# Altium schematic sheet PAGE2 - PWR, MODULE.SchDoc (continued)

## Library data of the parts
C1 C3 C8 = GRM21BR61A476ME15L: MURATA - GRM21BR61A476ME15L - CAP, MLCC, X5R, 47UF, 10V, 0805; Capacitance: 47; Capacitance Tolerance: ± 20%; Ceramic Capacitor Case: 0805 [2012 Metric]; Dielectric Characteristic: X5; Product Range: GRM Series; SVHC: No SVHC (17-Dec-2015; Voltage Rating: 10; Automotive Qualification Standard: -; Component Kind: Standard; ComponentLink1Description: http://www.farnell.com/datasheets/2079183.pdf; ComponentLink1URL: http://www.farnell.com/datasheets/2079183.pdf; Library Name: SCHLib.SchLib; Manufacturer: MURATA; Manufacturer Part Number: GRM21BR61A476ME15L; MSL: MSL 1 - Unlimited; Operating Temperature Max: 85; Operating Temperature Min: -55; Packaging: Cut Tape; Pricing 1: 5=0.699, 50=0.295, 250=0.232, 500=0.193, 1500=0.171 (GBP); RoHS: YES; Stock 1: 27604; Supplier 1: Farnell; Supplier Part Number 1: 2611939
C2 C4-C6 C20 = CGA4J1X5R1C106K125AC: TDK - CGA4J1X5R1C106K125AC - CAP, MLCC, X5R, 10UF, 16V, 0805; Component Kind: Standard; ComponentLink1Description: http://bcove.me/e1v54mtm; ComponentLink1URL: http://bcove.me/e1v54mtm; ComponentLink2Description: http://www.farnell.com/datasheets/1936565.pdf; ComponentLink2URL: http://www.farnell.com/datasheets/1936565.pdf; ComponentLink3Description: http://www.farnell.com/datasheets/1683658.pdf; ComponentLink3URL: http://www.farnell.com/datasheets/1683658.pdf; Library Name: SCHLib.SchLib; Manufacturer: TDK; Manufacturer Part Number: CGA4J1X5R1C106K125AC; Pricing 1: 5=0.41, 50=0.146, 250=0.131, 500=0.12, 1000=0.103 (GBP); RoHS: YES; Stock 1: 8974; Supplier 1: Farnell; Supplier Part Number 1: 2210948RL
C7 C16 C21 = 100nF: MURATA - GRM188R71C104KA01J - CAP, MLCC, X7R, 0.1UF, 16V, 0603; Capacitance: 0.1; Capacitance Tolerance: ± 10%; Ceramic Capacitor Case: 0603 [1608 Metric]; Dielectric Characteristic: X7; Product Range: GRM Series; SVHC: No SVHC (20-Jun-2016; Voltage Rating: 16; Automotive Qualification Standard: -; Component Kind: Standard; ComponentLink1Description: http://www.farnell.com/datasheets/2189124.pdf; ComponentLink1URL: http://www.farnell.com/datasheets/2189124.pdf; Library Name: SCHLib.SchLib; Manufacturer: MURATA; Manufacturer Part Number: GRM188R71C104KA01J; MSL: MSL 1 - Unlimited; Operating Temperature Max: 125; Operating Temperature Min: -55; Packaging: Cut Tape; Pricing 1: 10=0.0145, 100=0.0126, 500=0.0081, 2500=0.0059, 5000=0.0052, 10000=0.0046, 50000=0.0036, 100000=0.0035 (GBP); RoHS: YES; Stock 1: 18297; Supplier 1: Farnell; Supplier Part Number 1: 2688519
C9 C10 = GRM188R61C105KA12D: MURATA - GRM188R61C105KA12D - CAP, MLCC, X5R, 1UF, 16V, 0603; Capacitance: 1; Capacitance Tolerance: ± 10%; Capacitance Tolerance ±: ± 10%<!--FANDB--><div class="features-and-benefits-container"><div class="features-and-benefits-description"><span class="features-benefits-value">The Murata GRM series capacitors are Multilayer Ceramic Chip Capacitors (MLCC) used for general purpose applications. These MLCC capacitors are classified into temperature compensating and high dielectric constant types depending on the change in electrostatic capacitance due to change in temperature. The temperature compensating capacitors feature a small rate of change in electrostatic capacitance as the temperature changes and are used for applications such as filters and high frequency circuit matching. The high dielectric constant capacitors use materials with a high dielectric constant and feature a large electrostatic capacitance. Typical applications are power supply decoupling and smoothing circuits.</span></div><div class="features-and-benefits-list"><ul class="features-and-benefits-list-list"><li class="features-and-benefits-list-item">Large capacity and small size in a multilayer structure</li><li class="features-and-benefits-list-item">Capacitance value ranging from 0.1pF to 220?F</li><li class="features-and-benefits-list-item">Tin plated external electrodes, excellent solderability</li><li class="features-and-benefits-list-item">Provides good high frequency characteristics</li><li class="features-and-benefits-list-item">Excellent pulse response</li><li class="features-and-benefits-list-item">High reliability</li><li class="features-and-benefits-list-item">Capacitor size varies from 0.4×0.2mm to 5.7×5.0mm</li><li class="features-and-benefits-list-item">Rated voltage of 2.5VDC to 3.15; Ceramic Capacitor Case: 0603 [1608 Metric]; Dielectric Characteristic: X5; Product Range: GRM Series; SVHC: No SVHC (17-Dec-2015); Voltage Rating: 16; Automotive Qualification Standard: -; Component Kind: Standard; ComponentLink1Description: http://www.farnell.com/datasheets/2047881.pdf; ComponentLink1URL: http://www.farnell.com/datasheets/2047881.pdf; ComponentLink2Description: http://www.farnell.com/datasheets/2006461.pdf; ComponentLink2URL: http://www.farnell.com/datasheets/2006461.pdf; Library Name: SCHLib.SchLib; Manufacturer: MURATA; Manufacturer Part Number: GRM188R61C105KA12D; MSL: MSL 1 - Unlimited; Operating Temperature Max: 85; Operating Temperature Min: -55; Packaging: Cut Tape; Pricing 1: 10=0.0194, 100=0.013, 500=0.0101, 1000=0.0078, 2000=0.0074 (GBP); RoHS: YES; Stock 1: 27380; Supplier 1: Farnell; Supplier Part Number 1: 2362099RL
C11 C12 = GRM188R61C106MA73D: MURATA - GRM188R61C106MA73D - CAP, MLCC, X5R, 10UF, 16V, 0603; Capacitance: 10; Capacitance Tolerance: ± 20%; Ceramic Capacitor Case: 0603 [1608 Metric]; Dielectric Characteristic: X5; Product Range: GRM Series; SVHC: No SVHC (17-Dec-2015)<!--FANDB--><div class="features-and-benefits-container"><div class="features-and-benefits-description"><span class="features-benefits-value">The Murata GRM series capacitors are Multilayer Ceramic Chip Capacitors (MLCC) used for general purpose applications. These MLCC capacitors are classified into temperature compensating and high dielectric constant types depending on the change in electrostatic capacitance due to change in temperature. The temperature compensating capacitors feature a small rate of change in electrostatic capacitance as the temperature changes and are used for applications such as filters and high frequency circuit matching. The high dielectric constant capacitors use materials with a high dielectric constant and feature a large electrostatic capacitance. Typical applications are power supply decoupling and smoothing circuits.</span></div><div class="features-and-benefits-list"><ul class="features-and-benefits-list-list"><li class="features-and-benefits-list-item">Large capacity and small size in a multilayer structure</li><li class="features-and-benefits-list-item">Capacitance value ranging from 0.1pF to 220?F</li><li class="features-and-benefits-list-item">Tin plated external electrodes, excellent solderability</li><li class="features-and-benefits-list-item">Provides good high frequency characteristics</li><li class="features-and-benefits-list-item">Excellent pulse response</li><li class="features-and-benefits-list-item">High reliability</li><li class="features-and-benefits-list-item">Capacitor size varies from 0.4×0.2mm to 5.7×5.0mm</li><li class="features-and-benefits-list-item">Rated voltage of 2.5VDC to 3.15; Voltage Rating: 16; Automotive Qualification Standard: -; Component Kind: Standard; ComponentLink1Description: http://www.farnell.com/datasheets/2048226.pdf; ComponentLink1URL: http://www.farnell.com/datasheets/2048226.pdf; Library Name: SCHLib.SchLib; Manufacturer: MURATA; Manufacturer Part Number: GRM188R61C106MA73D; MSL: -; Operating Temperature Max: 85; Operating Temperature Min: -55; Packaging: Cut Tape; Pricing 1: 10=0.181, 100=0.103, 500=0.0863, 1000=0.0778, 2000=0.0677 (GBP); RoHS: YES; Stock 1: 21735; Supplier 1: Farnell; Supplier Part Number 1: 2426958
C13 C17 = C1206C221KGRACTU: KEMET - C1206C221KGRACTU - CAP, MLCC, X7R, 220PF, 2KV, 1206; Component Kind: Standard; ComponentLink1Description: http://www.farnell.com/datasheets/2180568.pdf; ComponentLink1URL: http://www.farnell.com/datasheets/2180568.pdf; Library Name: SCHLib.SchLib; Manufacturer: KEMET; Manufacturer Part Number: C1206C221KGRACTU; Pricing 1: 5=0.153, 50=0.0991, 250=0.0793, 500=0.0671, 1000=0.0581, 2500=0.0484, 12500=0.0415, 25000=0.0363 (GBP); RoHS: YES; Stock 1: 1984; Supplier 1: Farnell; Supplier Part Number 1: 2676444RL
C14 C15 C19 = GRM31CR61H106KA12L: MURATA - GRM31CR61H106KA12L - CAP, MLCC, X5R, 10UF, 50V, 1206; Component Kind: Standard; ComponentLink1Description: http://www.farnell.com/datasheets/2048767.pdf; ComponentLink1URL: http://www.farnell.com/datasheets/2048767.pdf; Library Name: SCHLib.SchLib; Manufacturer: MURATA; Manufacturer Part Number: GRM31CR61H106KA12L; Pricing 1: 5=0.368, 50=0.214, 250=0.196, 500=0.178, 1000=0.159 (GBP); RoHS: YES; Stock 1: 51830; Supplier 1: Farnell; Supplier Part Number 1: 1845762
C18 = GRM21BR71C105KA01L: MURATA - GRM21BR71C105KA01L - CAP, MLCC, X7R, 1UF, 16V, 0805; Component Kind: Standard; ComponentLink1Description: http://www.farnell.com/datasheets/2047889.pdf; ComponentLink1URL: http://www.farnell.com/datasheets/2047889.pdf; ComponentLink2Description: http://www.farnell.com/cad/1547313.zip; ComponentLink2URL: http://www.farnell.com/cad/1547313.zip; ComponentLink3Description: http://www.farnell.com/datasheets/2006461.pdf; ComponentLink3URL: http://www.farnell.com/datasheets/2006461.pdf; Library Name: SCHLib.SchLib; Manufacturer: MURATA; Manufacturer Part Number: GRM21BR71C105KA01L; Pricing 1: 5=0.0398, 50=0.0331, 250=0.0235, 500=0.022, 1500=0.018 (GBP); RoHS: YES; Stock 1: 58053; Supplier 1: Farnell; Supplier Part Number 1: 9527710
CM1 = RPI COMPUTE MODULE: RASPBERRY-PI - RPI COMPUTE MODULE - COMPUTE MODULE, RASPBERRY PI DEV BOARD; Silicon Manufacturer: Broadcom; Core Architecture: ARM; Core Sub-Architecture: ARM1176; For Use With: Raspberry Pi Compute Development Kit; Kit Contents: Compute Module BCM2835; Kit Features: Flexible & Rapid Prototype Development, Small & Powerful, Many More GPIOs & Interfaces<!--FANDB--><div class="features-and-benefits-container"><div class="features-and-benefits-desc-container"><span class="features-and-benefits-container-desc">Raspberry Pi Compute Module</span></div><div class="features-and-benefits-description"><span class="features-benefits-value">Flexible & Rapid Prototype Development, Small & Powerful, Many More GPIOs & Interfaces</span></div><div class="features-and-benefits-list"><ul class="features-and-benefits-list-list"><li class="features-and-benefits-list-item">Flexible & Rapid Prototype Development, Small & Powerful, Many More GPIOs & Interfaces</li></ul></div></div>; Silicon Core Number: BCM2835; Silicon Family Name: BCM2; ComponentLink1Description: http://bcove.me/qikksixg; ComponentLink1URL: http://bcove.me/qikksixg; ComponentLink2Description: http://www.farnell.com/datasheets/1829397.pdf; ComponentLink2URL: http://www.farnell.com/datasheets/1829397.pdf; Features: 512MB RAM, SODIMM Sized 6.5cm by 3cm Board, 200pin Edge Connector, On-board 4; Library Name: SCHLib.SchLib; Manufacturer: RASPBERRY-PI; Manufacturer Part Number: RPI COMPUTE MODULE; Pricing 1: 1=19.5 (GBP); Product Range: -; RoHS: YES; Supplier 1: Farnell; Supplier Part Number 1: 2427122
CON1 = RPi CM CON: DDR2 SODIMM connector for Raspberry Pi compute module 1/3/3-Lite; Manufacturer: AMP - TE CONNECTIVITY; Connector Type: Memory Socket; Contact Termination: Surface Mount Right Angle; Contact Termination Type: Surface Mount; No. of Contacts: 200; Pitch Spacing: 0.6; Range: Standard; Row Pitch: 6.2mm<!--FANDB--><div class="features-and-benefits-container"><div class="features-and-benefits-description"><span class="features-benefits-value">The 1473005-4 from TE Connectivity is a standard type DDR2 SODIMM socket. It is a 0.6mm pitch, 200 positions, semi hard tray DDR2 socket.</span></div><div class="features-and-benefits-list"><ul class="features-and-benefits-list-list"><li class="features-and-benefits-list-item">Right angled orientation</li><li class="features-and-benefits-list-item">2 rows and 2 bays</li><li class="features-and-benefits-list-item">DRAM voltage and SGRAM voltage?is 1.8; SVHC: No SVHC (15-Jun-2015); Component Kind: Standard; ComponentLink1Description: http://www.farnell.com/datasheets/2258267.pdf; ComponentLink1URL: http://www.farnell.com/datasheets/2258267.pdf; ComponentLink2Description: http://www.farnell.com/cad/1649684.pdf; ComponentLink2URL: http://www.farnell.com/cad/1649684.pdf; ComponentLink3Description: http://www.farnell.com/datasheets/582309.pdf; ComponentLink3URL: http://www.farnell.com/datasheets/582309.pdf; Contact Material: Copper; Contact Plating: Gold Plated Contacts; Lead Spacing: 0.6; Library Name: SCHLib.SchLib; Manufacturer Part Number: 1473005-4; Orientation: Right Angle; Pricing 1: 1=3.72, 25=2.82, 100=2.62, 250=2.53, 500=2.49, 2000=2.45, 3000=2.41, 5000=2.37 (GBP); Product Range: -; RoHS: YES; Stock 1: 735; Supplier 1: Farnell; Supplier Part Number 1: 1095877
CON2 = Camera con 15 pin: Raspberry Pi camera connector; Actuation Type: ZIF; Brand: TE Connectivity / AMP; Current Rating: 1 A; Gender: Female; Mounting Angle: Vertical; Number of Positions: 15 Position; Pitch: 1 mm; Voltage Rating: 125 V; Category: FFC & FPC Connectors; Component Kind: Standard; ComponentLink1Description: http://www.mouser.com/ds/2/418/NG_CD_1734248_E1__-658235.pdf; ComponentLink1URL: http://www.mouser.com/ds/2/418/NG_CD_1734248_E1__-658235.pdf; Contact Material: Phosphor Bronze; Contact Plating: Gold; Datasheet: http://www.mouser.com/ds/2/418/NG_CD_1734248_E1__-658235.pdf; Housing Material: Polyamide (PA); Library Name: SCHLib.SchLib; Manufacturer: TE Connectivity / AMP; Manufacturer Part Number: 1-1734248-5; Minimum Order Quantity: 1; Pricing 1: 1=1.67, 25=1.53, 50=1.42, 100=1.26, 500=1.17, 1000=1.10, 2500=0.952 (EUR); Product: Board Mount; Reeling: False; RoHS: RoHS Compliant; RoHS - Mouser: Y; Series: FPC; Standard Pack Qty: 1000; Stock 1: 1477; Supplier 1: Mouser; Supplier Part Number 1: 571-1-1734248-5; Temperature Range: - 20 C to + 85 C; Termination Style: SMD
CON3 = 188275-6: AMP - TE CONNECTIVITY - 188275-6 - CONNECTOR, RCPT, 6POS, 2ROW, 1.27MM; Component Kind: Standard; ComponentLink1Description: http://www.farnell.com/cad/1942471.pdf; ComponentLink1URL: http://www.farnell.com/cad/1942471.pdf; Library Name: SCHLib.SchLib; Manufacturer: AMP - TE CONNECTIVITY; Manufacturer Part Number: 188275-6; Pricing 1: 10=0.616, 50=0.561, 100=0.517, 250=0.482, 500=0.448, 1000=0.395 (GBP); RoHS: YES; Stock 1: 82; Supplier 1: Farnell; Supplier Part Number 1: 2452479
D1 D2 = HSMS-C190: BROADCOM LIMITED - HSMS-C190 - LED, RED, 10MCD, 626NM; Forward Current If: 20; Forward Voltage: 2.1; LED Mounting: SMD; Lens Shape: Rectangular; Luminous Intensity: 10; SVHC: No SVHC (17-Dec-2015)<!--FANDB--><div class="features-and-benefits-container"><div class="features-and-benefits-description"><span class="features-benefits-value">The HSMS-C190 is a HER SMD Chip LED with untinted/diffused lens, made of GaP. It is designed in an industry standard package 1.6 x 0.8mm footprint with 0.8mm profile. It is compatible with IR reflow solder processes. The small size and wide viewing angle make this LED prime choices for backlighting applications and front panel illumination especially where space is a premium.</span></div><div class="features-and-benefits-list"><ul class="features-and-benefits-list-list"><li class="features-and-benefits-list-item">Small size</li><li class="features-and-benefits-list-item">Industry standard footprint</li><li class="features-and-benefits-list-item">Compatible with IR solder</li><li class="features-and-benefits-list-item">Diffused optics</li><li class="features-and-benefits-list-item">-40 to 85; Viewing Angle: 170°; Wavelength Typ: 626; Automotive Qualification Standard: -; Bulb Size: -; Component Kind: Standard; ComponentLink1Description: http://www.farnell.com/datasheets/313031.pdf; ComponentLink1URL: http://www.farnell.com/datasheets/313031.pdf; ComponentLink2Description: http://www.farnell.com/datasheets/1681524.pdf; ComponentLink2URL: http://www.farnell.com/datasheets/1681524.pdf; ComponentLink3Description: http://www.farnell.com/datasheets/2165483.pdf; ComponentLink3URL: http://www.farnell.com/datasheets/2165483.pdf; LED Colour: Red; Library Name: SCHLib.SchLib; Manufacturer: BROADCOM LIMITED; Manufacturer Part Number: HSMS-C190; MSL: -; Packaging: Cut Tape; Pricing 1: 1=0.361, 10=0.256, 50=0.235, 100=0.106, 200=0.101 (GBP); Product Range: -; RoHS: YES; Stock 1: 7534; Supplier 1: Farnell; Supplier Part Number 1: 2497356
FID1 FID2 = FID_1MMC: Fiducial 40mil Diameter, 120mil Mask; Library Name: SCHLib.SchLib; Supplier: Digikey
L1 L2 = Inductor: Code_IEC: 1005; Code_JEITA: 0402; Component Kind: Standard; Datasheet: http://www.farnell.com/datasheets/1684089.pdf?_ga=1.209403153.2093911144.1482135861; LatestRevisionDate: 29-May-2009; LatestRevisionNote: IPC-7351 Footprint Added.; Library Name: Miscellaneous Devices.IntLib; PackageDescription: Chip Inductor; PackageReference: 0402-A; Published: 8-Jun-2000; Signal Integrity: Inductor; Simulation: INDUCTOR; Supplier 1: Farnell; Supplier 2: Farnell; Supplier Part Number 1: 2408944; Supplier Part Number 2: 2408944; Publisher: Altium Limited
L3 = LQH3NPN100MG0L: Fixed Inductors 1212 10uH 20%; Brand: Murata Electronics; Core Material: Ferrite Core; Maximum DC Resistance: 0.57 Ohms; Self Resonant Frequency: 45 MHz; Shielding: Shielded; Type: Power Wound Type Chip Coil Chip Inductors; Category: Fixed Inductors; Component Kind: Standard; ComponentLink1Description: http://www.mouser.com/ds/2/281/L0075S0103LQH3NP_M0-1074877.pdf; ComponentLink1URL: http://www.mouser.com/ds/2/281/L0075S0103LQH3NP_M0-1074877.pdf; Diameter: -; Height: 0.9 mm; Inductance: 10 uH; Lead Diameter: -; Lead Spacing: -; Length: 3 mm; Library Name: SCHLib.SchLib; Manufacturer: Murata Electronics; Manufacturer Part Number: LQH3NPN100MG0L; Maximum DC Current: 500 mA; Minimum Order Quantity: 1; Mounting Style: SMD/SMT; Operating Temperature Range: - 40 C to + 125 C; Package/Case: 1212 (3030 metric); Packaging: Reel; Pricing 1: 1=0.396, 10=0.303, 100=0.243, 500=0.210, 1500=0.146, 3000=0.138, 9000=0.125, 24000=0.122, 49500=0.115 (EUR); Product: Power Inductors; Q Minimum: -; Reeling: True; RoHS: RoHS Compliant; RoHS - Mouser: Y; Series: LQH N; Standard Pack Qty: 1500; Stock 1: 1981; Supplier 1: Mouser; Supplier Part Number 1: 81-LQH3NPN100MG0L; Termination Style: SMD/SMT; Test Frequency: -; Tolerance: 20 %; Width: 3 mm
LED1 = WS2812: LED RGB WS2812 OR SK6812 1=10; Manufacturer: Adafruit Industries LLC; Manufacturer Part Number: 1655; Color: Red, Green, Blue (RGB); Configuration: Discrete; Interface: Serial (Shift Register); Number of LEDs: 10; Utilized LED: SK6812 or WS2812; Category: Optoelectronics; Component Kind: Standard; ComponentLink1Description: https://cdn-shop.adafruit.com/product-files/1138/SK6812+LED+datasheet+.pdf; ComponentLink1URL: https://cdn-shop.adafruit.com/product-files/1138/SK6812+LED+datasheet+.pdf; Controller Type: -; Library Name: SCHLib.SchLib; Mounting Type: Surface Mount; Part Status: Active; Pricing 1: 1=4.5, 10=4.05 (USD); RoHS: RoHS Compliant; Size / Dimension: 5.00mm L x 5.00mm W; Stock 1: 7416; Supplier 1: Digi-Key; Supplier Part Number 1: 1528-1104-ND
LINK1 = QPC02SXGN-RC: CONN JUMPER SHORTING .100" GOLD; Manufacturer: Sullins Connector Solutions; .Checked SCH: used; Gender: Female Sockets; Number of Positions or Pins (Grid): 2 (1 x 2); Pitch: 0.100" (2.54mm); Category: Connectors, Interconnects; Color: -; ComponentLink1Description: http://www.sullinscorp.com/drawings/284_SXGN_11510.pdf; ComponentLink1URL: http://www.sullinscorp.com/drawings/284_SXGN_11510.pdf; Contact Finish: Gold; Contact Finish Thickness: Flash; Height: 0.235" (5.97mm); Library Name: SCHLib.SchLib; Manufacturer Part Number: QPC02SXGN-RC; Packaging: Bulk; Pricing 1: 1=0.11, 10=0.066, 25=0.0468, 50=0.0384, 100=0.033, 250=0.02792, 500=0.0258, 1000=0.0228, 2500=0.018 (USD); RoHS: RoHS Compliant; Stock 1: 23274; Supplier 1: Digi-Key; Supplier Part Number 1: S9337-ND; Type: Open Top
MH1 MH3-MH6 = MH_7.4MMNC_3.2MMD: Mounting Hole 7.4mm Diameter (Non Copper), 3.2mm Drill NPTH; Library Name: 28Pins_Project_V1I1.SCHLIB
P3 = MHDR2X15: Header, 15-Pin, Dual row; Component Kind: Standard; LatestRevisionDate: 17-Jul-2002; LatestRevisionNote: Re-released for DXP Platform.; Library Name: SCHLib.SchLib; Publisher: Altium Limited
P5 = MHDR2X5: Manufacturer: HARWIN; Manufacturer Part Number: M20-9980545; Contact Resistance: 15; Contact Termination: Through Hole Vertical; Contact Termination Type: Through Hole; Current Rating: 3; Gender: Header; Insulation Resistance: 10000; No. of Contacts: 10; No. of Mating Cycles: 300; No. of Rows: 2; Pitch Spacing: 2.54; Product Range: M20 Series; Proof Voltage: 750; SVHC: No SVHC (12-Jan-2017); Working Voltage: 750V<!--FANDB--><div class="features-and-benefits-container"><div class="features-and-benefits-description"><span class="features-benefits-value">The M20-998 series from Harwin are 2.54mm pitch, double row DIL vertical PC tail pin headers.</span></div><div class="features-and-benefits-list"><ul class="features-and-benefits-list-list"><li class="features-and-benefits-list-item">6.1mm mating height</li><li class="features-and-benefits-list-item">Number of contacts varies from 2 to 40 per row</li><li class="features-and-benefits-list-item">Available in gold and tin over nickel finish</li><li class="features-and-benefits-list-item">Contact material is brass</li><li class="features-and-benefits-list-item">UL94 V-0 black colour high temperature polyamide (Nylon) 6T moulding</li><li class="features-and-benefits-list-item">Current rating is 3A maximum</li><li class="features-and-benefits-list-item">Contact resistance is 25mohm maximum (gold) and 30mohm maximum (tin)</li><li class="features-and-benefits-list-item">Operating temperature range -40°C to 105°C</li><li class="features-and-benefits-list-item">Durability of 300 operations (gold) and 50 operation (tin)</li><li class="features-and-benefits-list-item">Dielectric strength of 750; Component Kind: Standard; ComponentLink1Description: http://www.farnell.com/datasheets/1089584.pdf; ComponentLink1URL: http://www.farnell.com/datasheets/1089584.pdf; ComponentLink2Description: http://www.farnell.com/cad/112195.pdf; ComponentLink2URL: http://www.farnell.com/cad/112195.pdf; ComponentLink3Description: http://www.farnell.com/datasheets/1712126.pdf; ComponentLink3URL: http://www.farnell.com/datasheets/1712126.pdf; Contact Material: Phosphor Bronze; Contact Plating: Gold Plated Contacts; Lead Spacing: 2.54; Library Name: SCHLib.SchLib; Operating Temperature Max: 105; Operating Temperature Min: -40; Pricing 1: 10=0.237, 20=0.226, 50=0.216, 100=0.205, 250=0.2, 500=0.195, 1000=0.163, 2500=0.135 (GBP); RoHS: YES; Stock 1: 13836; Supplier 1: Farnell; Supplier Part Number 1: 1022235; Termination Method: Solder; Voltage Rating V AC: 750
Q1 Q2 = DMG1012T-7: MOSFET MOSFET N-CHANNEL SOT-523; Brand: Diodes Incorporated; Channel Mode: Enhancement; Configuration: 1 N-Channel; Fall Time: 12.3 ns; Id - Continuous Drain Current: 630 mA; Number of Channels: 1 Channel; Pd - Power Dissipation: 280 mW; Qg - Gate Charge: 736.6 pC; Rds On - Drain-Source Resistance: 300 mOhms; Rise Time: 7.4 ns; Typical Turn-Off Delay Time: 26.7 ns; Typical Turn-On Delay Time: 5.1 ns; Vds - Drain-Source Breakdown Voltage: 20 V; Vgs - Gate-Source Voltage: +/- 6 V; Vgs th - Gate-Source Threshold Voltage: 500 mV; Category: MOSFET; Component Kind: Standard; ComponentLink1Description: http://www.mouser.com/ds/2/115/ds31783-44973.pdf; ComponentLink1URL: http://www.mouser.com/ds/2/115/ds31783-44973.pdf; Library Name: SCHLib.SchLib; Manufacturer: Diodes Incorporated; Manufacturer Part Number: DMG1012T-7; Maximum Operating Temperature: + 150 C; Minimum Operating Temperature: - 55 C; Minimum Order Quantity: 1; Mounting Style: SMD/SMT; Package/Case: SOT-523-3; Packaging: Reel; Pricing 1: 1=0.273, 10=0.187, 100=0.077, 1000=0.053, 3000=0.041, 9000=0.035, 24000=0.033, 45000=0.031, 99000=0.027 (EUR); Product: MOSFET Small Signal; Reeling: True; RoHS: RoHS Compliant; RoHS - Mouser: Y; Series: DMG1012; Standard Pack Qty: 3000; Stock 1: 1195; Supplier 1: Mouser; Supplier Part Number 1: 621-DMG1012T-7; Technology: Si; Transistor Polarity: N-Channel; Transistor Type: 1 N-Channel
R1 R2 R4 = MCMR12X000 PTL: MULTICOMP - MCMR12X000 PTL - RES, CERAMIC, 0R, 0.05OHM, 0.25W, 1206; Automotive Qualification Standard: -<!--FANDB--><div class="features-and-benefits-container"><div class="features-and-benefits-description"><span class="features-benefits-value">The Multicomp MCMR series resistors are automotive grade, anti sulphured thick film chip resistor. The resistance range includes E24 and E96 decade values from 1ohm to 10Mohm. These resistors are constructed on top of a high grade ceramic body.</span></div><div class="features-and-benefits-list"><ul class="features-and-benefits-list-list"><li class="features-and-benefits-list-item">EIA 0402, 0603, 0805, 1206 chip sizes available</li><li class="features-and-benefits-list-item">High reliability and stability</li><li class="features-and-benefits-list-item">Automotive grade AEC-Q200 compliant</li><li class="features-and-benefits-list-item">Complaint with (IEC 60068-1) 55/155/56 climatic category</li><li class="features-and-benefits-list-item">Maximum operation voltage of 50V, 75V, 150V and 200V</li><li class="features-and-benefits-list-item">Power rating of 62.5mW, 100mW, 125mW and 250mW</li><li class="features-and-benefits-list-item">Available in ±1% and ±5% tolerance</li><li class="features-and-benefits-list-item">Temperature coefficient (TCR) of +200ppm/°C, +100ppm/°C and -200ppm/°C to +400ppm/°C</li><li class="features-and-benefits-list-item">Operating temperature range -55°C to 155; Power Rating: 250; Product Range: MCMR Series; Resistance: 0; Resistance Tolerance: ± 0.05; Resistor Case Style: 1206 [3216 Metric]; Temperature Coefficient: -200ppm/°C to +400; Voltage Rating: 200; Component Kind: Standard; ComponentLink1Description: http://www.farnell.com/datasheets/1716709.pdf; ComponentLink1URL: http://www.farnell.com/datasheets/1716709.pdf; Library Name: SCHLib.SchLib; Manufacturer: MULTICOMP; Manufacturer Part Number: MCMR12X000 PTL; Packaging: Cut Tape; Pricing 1: 10=0.0252, 100=0.0179, 500=0.0111, 1000=0.0092, 2500=0.0069, 5000=0.0055, 25000=0.0037, 50000=0.0029 (GBP); Resistor Element Material: Ceramic; RoHS: Y-EX; Stock 1: 526857; Supplier 1: Farnell; Supplier Part Number 1: 2073874
R3 R5 R6 R10 = MCMR06X104 JTL: MULTICOMP - MCMR06X104 JTL - RES, CERAMIC, 100K, 5%, 0.1W, 0603; Power Rating: 100; Product Range: MCMR Series; Resistor Case Style: 0603 [1608 Metric]; Resistor Mounting: Surface Mount Device<!--FANDB--><div class="features-and-benefits-container"><div class="features-and-benefits-description"><span class="features-benefits-value">The Multicomp MCMR series resistors are automotive grade, anti sulphured thick film chip resistor. The resistance range includes E24 and E96 decade values from 1ohm to 10Mohm. These resistors are constructed on top of a high grade ceramic body.</span></div><div class="features-and-benefits-list"><ul class="features-and-benefits-list-list"><li class="features-and-benefits-list-item">EIA 0402, 0603, 0805, 1206 chip sizes available</li><li class="features-and-benefits-list-item">High reliability and stability</li><li class="features-and-benefits-list-item">Automotive grade AEC-Q200 compliant</li><li class="features-and-benefits-list-item">Complaint with (IEC 60068-1) 55/155/56 climatic category</li><li class="features-and-benefits-list-item">Maximum operation voltage of 50V, 75V, 150V and 200V</li><li class="features-and-benefits-list-item">Power rating of 62.5mW, 100mW, 125mW and 250mW</li><li class="features-and-benefits-list-item">Available in ±1% and ±5% tolerance</li><li class="features-and-benefits-list-item">Temperature coefficient (TCR) of +200ppm/°C, +100ppm/°C and -200ppm/°C to +400ppm/°C</li><li class="features-and-benefits-list-item">Operating temperature range -55°C to 155; Temperature Coefficient: ± 100; Voltage Rating: 75; Automotive Qualification Standard: -; Component Kind: Standard; ComponentLink1Description: http://www.farnell.com/datasheets/1716707.pdf; ComponentLink1URL: http://www.farnell.com/datasheets/1716707.pdf; Library Name: SCHLib.SchLib; Manufacturer: MULTICOMP; Manufacturer Part Number: MCMR06X104 JTL; Packaging: Cut Tape; Pricing 1: 10=0.0109, 100=0.0085, 500=0.006, 1000=0.0048, 2500=0.004, 5000=0.003, 25000=0.002, 50000=0.0016 (GBP); Resistance: 100; Resistance Tolerance: ± 5%; Resistor Element Material: Ceramic; RoHS: Y-EX; Stock 1: 187; Supplier 1: Farnell; Supplier Part Number 1: 2073357
R7 R8 R20 = MCMR06X471 JTL: MULTICOMP - MCMR06X471 JTL - RES, CERAMIC, 470R, 5%, 0.1W, 0603; Component Kind: Standard; ComponentLink1Description: http://www.farnell.com/datasheets/1716707.pdf; ComponentLink1URL: http://www.farnell.com/datasheets/1716707.pdf; Library Name: SCHLib.SchLib; Manufacturer: MULTICOMP; Manufacturer Part Number: MCMR06X471 JTL; Pricing 1: 10=0.0089, 100=0.0076, 500=0.0063, 1000=0.0049, 2500=0.0033, 5000=0.0024, 25000=0.0016, 50000=0.0013 (GBP); RoHS: Y-EX; Stock 1: 3786; Supplier 1: Farnell; Supplier Part Number 1: 2073513
R9 = MCMR06X4702FTL: MULTICOMP - MCMR06X4702FTL - RES, CERAMIC, 47K, 1%, 0.1W, 0603; Automotive Qualification Standard: -<!--FANDB--><div class="features-and-benefits-container"><div class="features-and-benefits-description"><span class="features-benefits-value">The Multicomp MCMR series resistors are automotive grade, anti sulphured thick film chip resistor. The resistance range includes E24 and E96 decade values from 1ohm to 10Mohm. These resistors are constructed on top of a high grade ceramic body.</span></div><div class="features-and-benefits-list"><ul class="features-and-benefits-list-list"><li class="features-and-benefits-list-item">EIA 0402, 0603, 0805, 1206 chip sizes available</li><li class="features-and-benefits-list-item">High reliability and stability</li><li class="features-and-benefits-list-item">Automotive grade AEC-Q200 compliant</li><li class="features-and-benefits-list-item">Complaint with (IEC 60068-1) 55/155/56 climatic category</li><li class="features-and-benefits-list-item">Maximum operation voltage of 50V, 75V, 150V and 200V</li><li class="features-and-benefits-list-item">Power rating of 62.5mW, 100mW, 125mW and 250mW</li><li class="features-and-benefits-list-item">Available in ±1% and ±5% tolerance</li><li class="features-and-benefits-list-item">Temperature coefficient (TCR) of +200ppm/°C, +100ppm/°C and -200ppm/°C to +400ppm/°C</li><li class="features-and-benefits-list-item">Operating temperature range -55°C to 155; Power Rating: 100; Product Range: MCMR Series; Resistance: 47; Resistor Case Style: 0603 [1608 Metric]; Temperature Coefficient: ± 100; Voltage Rating: 75; Component Kind: Standard; ComponentLink1Description: http://www.farnell.com/datasheets/1716707.pdf; ComponentLink1URL: http://www.farnell.com/datasheets/1716707.pdf; Library Name: SCHLib.SchLib; Manufacturer: MULTICOMP; Manufacturer Part Number: MCMR06X4702FTL; Packaging: Cut Tape; Pricing 1: 10=0.0115, 100=0.009, 500=0.0064, 1000=0.0051, 2500=0.0042, 5000=0.0032, 25000=0.0021, 50000=0.0017 (GBP); Resistance Tolerance: ± 1%; Resistor Element Material: Ceramic; RoHS: Y-EX; Stock 1: 21427; Supplier 1: Farnell; Supplier Part Number 1: 2073510
R13 R14 = CRCW06031K80FKEA: VISHAY - CRCW06031K80FKEA - RES, THICK FILM, 1K8, 1%, 0.1W, 0603; Component Kind: Standard; ComponentLink1Description: http://www.farnell.com/datasheets/2111203.pdf; ComponentLink1URL: http://www.farnell.com/datasheets/2111203.pdf; ComponentLink2Description: http://www.farnell.com/cad/1552026.zip; ComponentLink2URL: http://www.farnell.com/cad/1552026.zip; Library Name: SCHLib.SchLib; Manufacturer: VISHAY; Manufacturer Part Number: CRCW06031K80FKEA; Pricing 1: 10=0.0105, 100=0.0094, 500=0.0055, 1000=0.005, 2500=0.0038 (GBP); RoHS: Y-EX; Stock 1: 21649; Supplier 1: Farnell; Supplier Part Number 1: 1652854
R15-R19 R21-R26 = CR0603-J/-000ELF: BOURNS - CR0603-J/-000ELF - RES, THICK FILM, 0R, 5%, 0.1W, 0603; Power Rating: 100; Product Range: CR Series; Resistance: 0; Resistor Case Style: 0603 [1608 Metric]; Resistor Mounting: Surface Mount Device<!--FANDB--><div class="features-and-benefits-container"><div class="features-and-benefits-description"><span class="features-benefits-value">The Bourns CR series are standard thick film chip resistors. These resistors provide flexibility of performance, space saving and low cost. The Resistance range includes E96 and E24 decade values from 1ohm to 10Mohm.</span></div><div class="features-and-benefits-list"><ul class="features-and-benefits-list-list"><li class="features-and-benefits-list-item">0201, 0402, 0603, 0805, 1206, 1210, 2010, 2512 standard case sizes available</li><li class="features-and-benefits-list-item">±1% and ±5% tolerance</li><li class="features-and-benefits-list-item">±100ppm/°C, ±200ppm/°C and ±250ppm/°C TCR values available</li><li class="features-and-benefits-list-item">Power rating selectable for 50mW, 63mW, 0.1W, 0.125W, 0.25W, 0.5W and 1W based on ordering</li><li class="features-and-benefits-list-item">Jumpers are available for all size</li><li class="features-and-benefits-list-item">3 layer termination process with nickel barrier prevents leaching & provides excellent solderability</li><li class="features-and-benefits-list-item">Suitable for all type of soldering processes</li><li class="features-and-benefits-list-item">Tight dimensional tolerances</li><li class="features-and-benefits-list-item">Maximum working voltage depends on case size</li><li class="features-and-benefits-list-item">Operating temperature of -55°C to +125°C(CR0201) and -55°C to +155; SVHC: No SVHC (17-Dec-2015); Voltage Rating: 50; Automotive Qualification Standard: -; Component Kind: Standard; ComponentLink1Description: http://www.bourns.com/engineering/CHPREZTR/cr0603.stp; ComponentLink1URL: http://www.bourns.com/engineering/CHPREZTR/cr0603.stp; ComponentLink2Description: http://www.farnell.com/datasheets/2178762.pdf; ComponentLink2URL: http://www.farnell.com/datasheets/2178762.pdf; Library Name: SCHLib.SchLib; Manufacturer: BOURNS; Manufacturer Part Number: CR0603-J/-000ELF; MSL: MSL 1 - Unlimited; Packaging: Cut Tape; Pricing 1: 10=0.0146, 250=0.0097, 1000=0.0073, 5000=0.0049, 12500=0.0042 (GBP); Resistance Tolerance: ± 5%; Resistor Element Material: Thick Film; RoHS: YES; Stock 1: 58200; Supplier 1: Farnell; Supplier Part Number 1: 2008343; Temperature Coefficient: -
SW1 = 450302014072: WURTH ELEKTRONIK - 450302014072 - SWITCH, SLIDE, MINI, ON-ON, RIGHT ANGLE; Contact Configuration: SPDT; Contact Current Max: 500; Contact Resistance: 30; Contact Voltage DC Max: 12; Switch Mounting: Through Hole; Component Kind: Standard; ComponentLink1Description: http://www.farnell.com/datasheets/1913864.pdf; ComponentLink1URL: http://www.farnell.com/datasheets/1913864.pdf; Contact Voltage AC Max: -; Library Name: SCHLib.SchLib; Manufacturer: WURTH ELEKTRONIK; Manufacturer Part Number: 450302014072; Operating Temperature Max: 85; Operating Temperature Min: -40°C<!--FANDB--><div class="features-and-benefits-container"><div class="features-and-benefits-description"><span class="features-benefits-value">The 450302014072 is a 3.5 x 4.7mm surface mount J-bend side push Switch with 220 ±50g operation force and boss design. It comes with gold-plated contact and UL94V-0 rated PA66 housing. The 436333045822 features SPDT contact configuration.</span></div><div class="features-and-benefits-list"><ul class="features-and-benefits-list-list"><li class="features-and-benefits-list-item">UL94V-0 Flame-rated insulator</li><li class="features-and-benefits-list-item">10000; Orientation: Right Angle; Pricing 1: 1=1.86, 100=1.46, 500=1.43, 900=1.4, 3000=1.37, 6000=1.34, 9000=1.31 (GBP); Product Range: -; RoHS: YES; Stock 1: 395; Supplier 1: Farnell; Supplier Part Number 1: 2134453; Switch Operation: On-On
TP1 TP2 TP4-TP6 TP9 = TEST_POINT: Test point; Library Name: SCHLib.SchLib
U1 = PAM2306AYPKE: Voltage Regulators - Switching Regulators Dual PWM Step-Down 40uA 1A 1.5MHz; Brand: Diodes Incorporated; Input Voltage: 2.5 V to 5.5 V; Input Voltage MAX: 5.5 V; Input Voltage MIN: 2.5 V; Load Regulation: 0.5 %; Number of Outputs: 2 Output; Output Voltage: 1.8 V, 3.3 V; Topology: Buck; Category: Voltage Regulators - Switching Regulators; Component Kind: Standard; ComponentLink1Description: http://www.mouser.com/ds/2/115/PAM2306-336770.pdf; ComponentLink1URL: http://www.mouser.com/ds/2/115/PAM2306-336770.pdf; Library Name: SCHLib.SchLib; Manufacturer: Diodes Incorporated; Manufacturer Part Number: PAM2306AYPKE; Maximum Operating Temperature: + 85 C; Minimum Operating Temperature: - 40 C; Minimum Order Quantity: 1; Mounting Style: SMD/SMT; Operating Supply Current: 40 uA; Operating Temperature Range: - 40 C to + 85 C; Output Current: 1 A; Package/Case: WDFN-EP-12; Packaging: Reel; Pricing 1: 1=0.717, 10=0.555, 100=0.358, 1000=0.287, 3000=0.242, 9000=0.233 (EUR); Reeling: True; RoHS: RoHS Compliant; RoHS - Mouser: Y; Series: PAM2306; Shutdown: Shutdown; Standard Pack Qty: 3000; Stock 1: 166; Supplier 1: Mouser; Supplier Part Number 1: 621-PAM2306AYPKE; Supply Voltage - Min: 2.5 V; Switching Frequency: 1.5 MHz; Tradename: PAM2306; Type: PWM Step-Down DC-DC Converter
U2 = REG1117: TEXAS INSTRUMENTS - REG1117 - V REG LDO ADJ +1.5/13.7V, SMD, 1117; Accuracy %: 1%; Adjustable Output Voltage Max: 13.5; Adjustable Output Voltage Min: 1.5; Base Number: 1117; Dropout Voltage Vdo: 1.1; Input Voltage Max: 15; Input Voltage Min: 3.8; LDO Regulator Case Style: SOT-223; No. of Outputs: 1; No. of Pins: 3; Output Current: 800; Output Current Max: 0.8; Output Type: Adjustable; Output Voltage Adjustable Range: 1.25V to 10; SVHC: No SVHC (15-Jun-2015); Voltage Regulator Type: Positive Adjustable<!--FANDB--><div class="features-and-benefits-container"><div class="features-and-benefits-description"><span class="features-benefits-value">The REG1117 is a 800mA low dropout Positive Regulator with variety of fixed-and adjustable-voltage versions. Output voltage of the adjustable versions is set with two external resistors. The REG1117 low dropout voltage allows its use with as little as 1; Automotive Qualification Standard: -; Component Kind: Standard; ComponentLink1Description: http://www.farnell.com/datasheets/1919259.pdf; ComponentLink1URL: http://www.farnell.com/datasheets/1919259.pdf; Fixed Output Voltage Nom.: -; Library Name: SCHLib.SchLib; Manufacturer: TEXAS INSTRUMENTS; Manufacturer Part Number: REG1117; MSL: MSL 1 - Unlimited; Operating Temperature Max: 125; Operating Temperature Min: -40; Operating Temperature Range: 0°C to +125; Operating Voltage Tolerance +: 1%; Output Voltage: 13.5; Output Voltage Max: 13.5; Output Voltage Min: 1.5; Packaging: Each; Pricing 1: 1=2.02, 10=1.53, 100=1.23, 250=1.06, 1000=0.887, 3000=0.861, 6000=0.835, 10000=0.809 (GBP); Primary Input Voltage: 15; Product Range: -; RoHS: TBA; Stock 1: 611; Supplier 1: Farnell; Supplier Part Number 1: 1097566; Supply Voltage Min: 3.8; Termination Type: Surface Mount Device
U3 = ITX2409SA: Isolated Board Mount DC/DC Converter, 2:1 Input, Fixed, 1 Output, 18 V, 36 V, 6 W, 9 V; Manufacturer: XP POWER; DC / DC Converter Mounting: Through Hole; Input Voltage DC Max: 36; Input Voltage DC Min: 18; Output Current - Output 1: 666; Output Power Max: 6; Output Voltage - Output 1: 9; Product Range: ITX Series<!--FANDB--><div class="features-and-benefits-container"><div class="features-and-benefits-description"><span class="features-benefits-value">The ITX series isolated 6W DC-DC Converter.</span></div><div class="features-and-benefits-list"><ul class="features-and-benefits-list-list"><li class="features-and-benefits-list-item">Single and dual outputs</li><li class="features-and-benefits-list-item">Remote control option</li><li class="features-and-benefits-list-item">2; Approvals & Standards: -; Component Kind: Standard; ComponentLink1Description: http://www.farnell.com/datasheets/1816507.pdf; ComponentLink1URL: http://www.farnell.com/datasheets/1816507.pdf; DC / DC Converter Output Type: Fixed; DC / DC Converter Type: -; Depth: 21.85; Height: 11.1; Library Name: SCHLib.SchLib; Manufacturer Part Number: ITX2409SA; No. of Outputs: 1 Output; Output Current - Output 2: -; Output Current - Output 3: -; Output Voltage - Output 2: -; Output Voltage - Output 3: -; Pricing 1: 1=15.41, 5=14.95, 10=14.49, 25=13.87, 100=12.95 (GBP); RoHS: YES; Supplier 1: Farnell; Supplier 2: Digi-Key; Supplier Part Number 1: 2422810; Supplier Part Number 2: 1470-2544-5-ND; Width: 9.2
U4 = SPM15-050-Q12P-C: Isolated Board Mount DC/DC Converter, Medical, Fixed, 1 Output, 9 V, 36 V, 15 W, 5 V; Manufacturer: MURATA POWER SOLUTIONS; Approvals & Standards: CAN, CSA, IEC, UL; Connector Mounting: PCB Mount; Connector Mounting Orientation: Surface Mount; Connector Type: SFP; Contact Termination: Solder; DC / DC Converter Mounting: Through Hole; DC / DC Converter Type: DIP; Gender: Receptacle; Input Voltage DC Max: 36; Input Voltage DC Min: 9; No. of Contacts: 20; Output Current - Output 1: 3; Output Power Max: 15; Output Voltage - Output 1: 5; Product Range: SPM15 Series; SVHC: No SVHC (17-Dec-2015)<!--FANDB--><div class="features-and-benefits-container"><div class="features-and-benefits-description"><span class="features-benefits-value">The SPM15-050-Q12P-C is an isolated DC-DC Converter represents the next generation in Industrial Potted Module Technology. Featuring a full 15W output in one square inch of board area, the device isolated DC-DC converter offers efficient regulated DC power for printed circuit board mounting. The 1 x 1 x 0.41-inch converter accepts a wide range of input voltages, ideal for industrial applications. The converter offers a feature/option set including through-hole mounting, positive or negative logic (remote ON/OFF), overcurrent and overtemperature protection, under-voltage lockout. The input voltage range covers the standard Industrial requirements with a regulated output voltage and power rating up to 15W. Modules provide voltage isolation (basic insulation) from input to output of up to 1600V. .The Module delivers full output power to 70°C with no airflow. It is ideal for applications that do not require any heat sinking or forced air cooling.</span></div><div class="features-and-benefits-list"><ul class="features-and-benefits-list-list"><li class="features-and-benefits-list-item">High efficiency</li><li class="features-and-benefits-list-item">Monotonic start-up</li><li class="features-and-benefits-list-item">Continuous short circuit protection</li><li class="features-and-benefits-list-item">Low output ripple and noise</li><li class="features-and-benefits-list-item">Strong thermal derating characteristics</li><li class="features-and-benefits-list-item">Positive ON/OFF control logic</li><li class="features-and-benefits-list-item">1600V I/O isolation</li><li class="features-and-benefits-list-item">±0.1 Load and ±0.05% line maximum regulation</li><li class="features-and-benefits-list-item">88% Typical efficiency</li><li class="features-and-benefits-list-item">100; Colour: Black; Component Kind: Standard; ComponentLink1Description: http://www.farnell.com/datasheets/1932853.pdf; ComponentLink1URL: http://www.farnell.com/datasheets/1932853.pdf; ComponentLink2Description: http://www.farnell.com/datasheets/2239469.pdf; ComponentLink2URL: http://www.farnell.com/datasheets/2239469.pdf; ComponentLink3Description: http://www.tycoelectronics.com/commerce/DocumentDelivery/DDEController?Action=srchrtrv&DocNm=1367073-1&DocType=Customer+View+Model&DocLang=English; ComponentLink3URL: http://www.tycoelectronics.com/commerce/DocumentDelivery/DDEController?Action=srchrtrv&DocNm=1367073-1&DocType=Customer+View+Model&DocLang=English; ComponentLink4Description: http://www.farnell.com/cad/1794462.pdf; ComponentLink4URL: http://www.farnell.com/cad/1794462.pdf; ComponentLink5Description: http://www.tycoelectronics.com/commerce/DocumentDelivery/DDEController?Action=srchrtrv&DocNm=408-8495&DocType=Specification+Or+Standard&DocLang=English; ComponentLink5URL: http://www.tycoelectronics.com/commerce/DocumentDelivery/DDEController?Action=srchrtrv&DocNm=408-8495&DocType=Specification+Or+Standard&DocLang=English; ComponentLink6Description: http://www.farnell.com/datasheets/1650946.pdf; ComponentLink6URL: http://www.farnell.com/datasheets/1650946.pdf; Contact Material: Phosphor Bronze; Contact Plating: Gold Plated Contacts; Contact Termination Type: Solder; DC / DC Converter Output Type: Fixed; Depth: 25.4; Flammability Rating: UL 94V-0; Height: 10.41; Library Name: SCHLib.SchLib; Manufacturer Part Number: SPM15-050-Q12P-C; Material: Liquid Crystal Polymer (LCP); No. of Outputs: 1 Output; Output Current - Output 2: -; Output Current - Output 3: -; Output Voltage - Output 2: -; Output Voltage - Output 3: -; Packaging: Cut Tape; Pricing 1: 1=29.43, 10=29.19, 25=28.95, 50=28.71 (GBP); RoHS: Y-EX; Stock 1: 84; Supplier 1: Farnell; Supplier 2: Mouser; Supplier Part Number 1: 2470131; Supplier Part Number 2: 580-SPM15-050-Q12P-C; Termination Method: Solder<!--FANDB--><div class="features-and-benefits-container"><div class="features-and-benefits-description"><span class="features-benefits-value">The 1367073-1 is a 20-pin 1-port surface-mount SFP Connector with gold-plated phosphor bronze contacts and black LCP housing. The connector features integrated light pipes for port indicators, connectors with improved signal integrity, enhanced EMI springs for increased EMI suppression and riding heat sink technology for thermal management.</span></div><div class="features-and-benefits-list"><ul class="features-and-benefits-list-list"><li class="features-and-benefits-list-item">Supports applications up to 4Gbps</li><li class="features-and-benefits-list-item">Cages available in single port, ganged and stacked configurations</li><li class="features-and-benefits-list-item">Stacked cages have integrated connectors, press-fit in one process step</li><li class="features-and-benefits-list-item">Uses 20 position PT (pluggable transceiver) connector</li><li class="features-and-benefits-list-item">Direct attach copper cable assemblies for lower cost with short reach</li><li class="features-and-benefits-list-item">Integrated light pipes for port indicators</li><li class="features-and-benefits-list-item">Enhanced EMI springs for increased EMI suppression</li><li class="features-and-benefits-list-item">Riding heat sink technology for thermal management</li></ul></div><div class="features-and-benefits-applications"><ul class="features-and-benefits-value"><li class="features-and-benefits-list-item">Industrial</li></ul></div></div>; Width: 25.4
P1 = MHDR2X3H: Component Kind: Standard; Library Name: SCHLib.SchLib
